# Altium schematic sheet SWITCHING.SchDoc
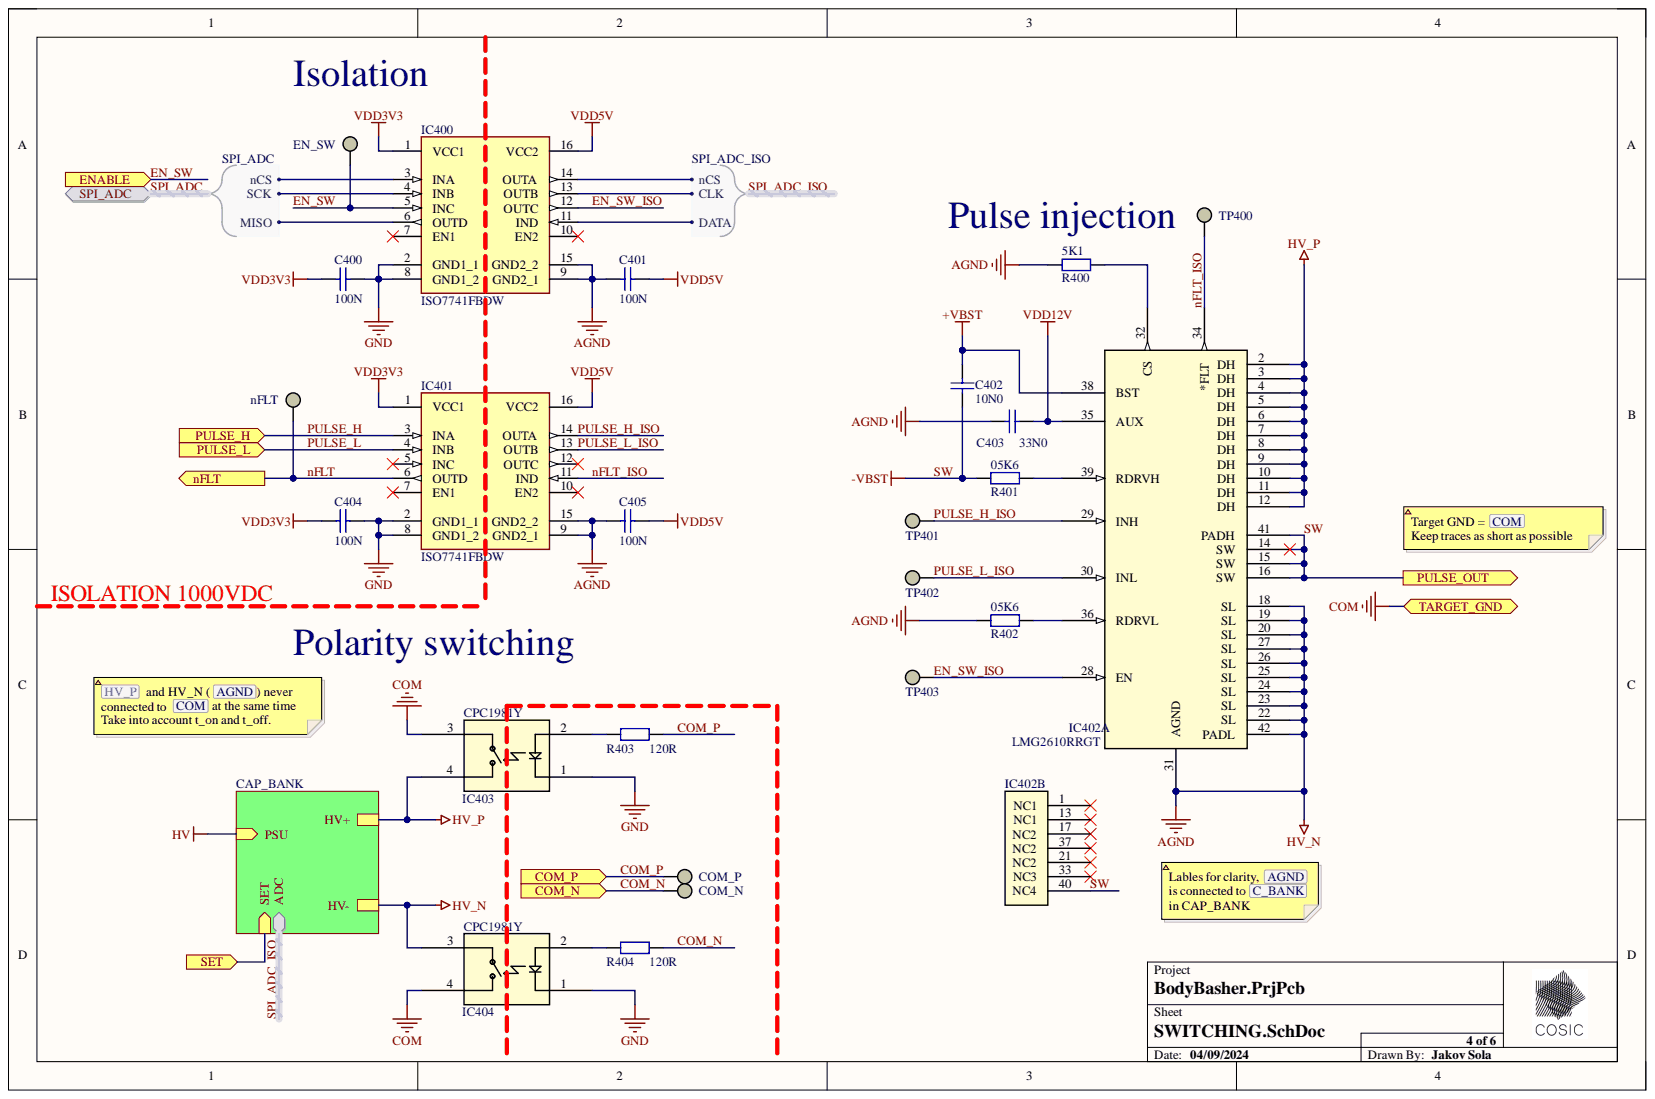
[diagram p0: SWITCHING.SchDoc]

## Parts
C400  value 100N  [CAPC0603N]  (hidden comment: Cap)
C401  value 100N  [CAPC0603N]  (hidden comment: Cap)
C402  VALUE_4C: 10N0  [CAPC0603N]  (hidden comment: Cap)
C403  VALUE_4C: 33N0  [CAPC0603N]  (hidden comment: Cap)
C404  value 100N  [CAPC0603N]  (hidden comment: Cap)
C405  value 100N  [CAPC0603N]  (hidden comment: Cap)
COM_N  TP  [TP]  (value not drawn)
COM_P  TP  [TP]  (value not drawn)
EN_SW  TP  [TP]  (value not drawn)
IC400  ISO7741FBDW  [SOIC16]
IC401  ISO7741FBDW  [SOIC16]
IC402  LMG2610RRGT  [XLMG2610RRGT]  (parts A,B)
IC403  SSR_SPST_NO  [CPC1981Y]  (value not drawn)
IC404  SSR_SPST_NO  [CPC1981Y]  (value not drawn)
nFLT  TP  [TP]  (value not drawn)
R400  value 5K1  [RESC0603N]  (hidden comment: Res)
R401  VALUE_4C: 05K6  [RESC0603N]  (hidden comment: Res)
R402  VALUE_4C: 05K6  [RESC0603N]  (hidden comment: Res)
R403  value 120R  [RESC0603N]  (hidden comment: Res)
R404  value 120R  [RESC0603N]  (hidden comment: Res)
TP400  TP  [TP]
TP401  TP  [TP]
TP402  TP  [TP]
TP403  TP  [TP]

## Ports
COM_N, COM_P, ENABLE, nFLT, PULSE_H, PULSE_L, PULSE_OUT, SET, SPI_ADC, SPI_ADC.MISO, SPI_ADC.nCS, SPI_ADC.SCK, TARGET_GND

## Nets
+VBST: C402.2 IC402.38(BST)
AGND: C401.2 C403.1 C405.2 IC400.9(GND2_1) IC400.15(GND2_2) IC401.9(GND2_1) IC401.15(GND2_2) IC402.18(SL) IC402.19(SL) IC402.20(SL) IC402.22(SL) IC402.23(SL) IC402.24(SL) IC402.25(SL) IC402.26(SL) IC402.27(SL) IC402.31(AGND) IC402.42(PADL) IC404.3 R400.1 R402.1
GND: C400.1 C404.1 IC400.2(GND1_1) IC400.8(GND1_2) IC401.2(GND1_1) IC401.8(GND1_2) IC403.1 IC404.1
HV_P: IC402.2(DH) IC402.3(DH) IC402.4(DH) IC402.5(DH) IC402.6(DH) IC402.7(DH) IC402.8(DH) IC402.9(DH) IC402.10(DH) IC402.11(DH) IC402.12(DH) IC403.4
VDD3V3: C400.2 C404.2 IC400.1(VCC1) IC401.1(VCC1)
VDD5V: C401.1 C405.1 IC400.16(VCC2) IC401.16(VCC2)
VDD12V: C403.2 IC402.35(AUX)
COM: IC403.3 IC404.4 ; port TARGET_GND
COM_N: COM_N.TP R404.2 ; port COM_N
COM_P: COM_P.TP R403.2 ; port COM_P
EN_SW: EN_SW.TP IC400.5(INC) ; port ENABLE
EN_SW_ISO: IC400.12(OUTC) IC402.28(EN) TP403.TP
nFLT: IC401.6(OUTD) nFLT.TP ; port nFLT
nFLT_ISO: IC401.11(IND) IC402.34(*FLT) TP400.TP
PULSE_H: IC401.3(INA) ; port PULSE_H
PULSE_H_ISO: IC401.14(OUTA) IC402.29(INH) TP401.TP
PULSE_L: IC401.4(INB) ; port PULSE_L
PULSE_L_ISO: IC401.13(OUTB) IC402.30(INL) TP402.TP
SPI_ADC.MISO: IC400.6(OUTD) ; port SPI_ADC
SPI_ADC.nCS: IC400.3(INA) ; port SPI_ADC
SPI_ADC.SCK: IC400.4(INB) ; port SPI_ADC
SPI_ADC_ISO.CLK: IC400.13(OUTB)
SPI_ADC_ISO.DATA: IC400.11(IND)
SPI_ADC_ISO.nCS: IC400.14(OUTA)
SW: C402.1 IC402.14(SW) IC402.15(SW) IC402.16(SW) IC402.40(NC4) IC402.41(PADH) R401.1 ; port PULSE_OUT
NetIC400_7: IC400.7(EN1)
NetIC400_10: IC400.10(EN2)
NetIC401_5: IC401.5(INC)
NetIC401_7: IC401.7(EN1)
NetIC401_10: IC401.10(EN2)
NetIC401_12: IC401.12(OUTC)
NetIC402_1: IC402.1(NC1)
NetIC402_13: IC402.13(NC1)
NetIC402_17: IC402.17(NC2)
NetIC402_21: IC402.21(NC2)
NetIC402_32: IC402.32(CS) R400.2
NetIC402_33: IC402.33(NC3)
NetIC402_36: IC402.36(RDRVL) R402.2
NetIC402_37: IC402.37(NC2)
NetIC402_39: IC402.39(RDRVH) R401.2
NetIC403_2: IC403.2 R403.1
NetIC404_2: IC404.2 R404.1
HV:
SET: ; port SET
SPI_ADC_ISO.MISO:
SPI_ADC_ISO.SCK:

## Library data of the parts
C400 C401 C404 C405 = CL10B104KA8WPNC: MANUF: SAMSUNG; Database Table Name: Fixed_capacitors$; DESCR: CAP 100N 0603 25V X7R 10%; POPULATE: YES; TOLERANCE: 0.1; VENDOR: DIGI-KEY; VENDORCODE: 1276-6717-1-ND; VOLTAGE: 25V; Component Kind: Standard; Library Name: Capacitors.DbLib; MANUFCODE: CL10B104KA8WPNC; PACKAGE: 0603; TECHNOLOGY: X7R; VALUE_4C: 100N
C402 = CGA3E2C0G1H103J080AA: MANUF: TDK; Database Table Name: Fixed_capacitors$; DESCR: CAP 10N0 0603 50V NP0 5%; POPULATE: YES; TOLERANCE: 0.05; VALUE: 10N; VALUE_PF: 10000; VENDOR: FARNELL INONE; VENDORCODE: 2210846; VOLTAGE: 50V; Component Kind: Standard; Library Name: Capacitors.DbLib; MANUFCODE: CGA3E2C0G1H103J080AA; PACKAGE: 0603; TECHNOLOGY: NP0
C403 = CL10B333KB8NFNC: MANUF: SAMSUNG; Database Table Name: Fixed_capacitors$; DESCR: CAP 33N0 0603 50V X7R 10%; POPULATE: YES; TOLERANCE: 0.1; VALUE: 33N; VENDOR: DIGI-KEY; VENDORCODE: 1276-2040-1-ND; VOLTAGE: 50V; Component Kind: Standard; Library Name: Capacitors.DbLib; MANUFCODE: CL10B333KB8NFNC; PACKAGE: 0603; TECHNOLOGY: X7R
COM_N COM_P EN_SW nFLT TP400-TP403 = TP: Database Table Name: Misc$; DESCR: TESTPOINT 1.5MM; POPULATE: NA; Component Kind: Standard; Library Name: Other_passive.Dblib; MANUFCODE: TP
IC400 IC401 = ISO7741FBDW: MANUF: TI; Database Table Name: IC$; DESCR: IC ISO 3/1 100MBPS 2.25-5.5 V; POPULATE: YES; VENDOR: MOUSER; VENDORCODE: 595-ISO7741FBDW; Component Kind: Standard; Library Name: Integrated_circuits.DbLib; MANUFCODE: ISO7741FBDW
IC402 = LMG2610RRGT: MANUF: TEXAS INSTRUMENTS; Database Table Name: IC$; DESCR: IC INT HALF BRIDGE GAN; POPULATE: YES; VENDOR: MOUSER; VENDORCODE: 595-LMG2610RRGT; Component Kind: Standard; Library Name: Integrated_circuits.DbLib; MANUFCODE: LMG2610RRGT
IC403 IC404 = CPC1981Y: MANUF: IXYS; Database Table Name: IC$; DESCR: IC SSR SPST NO 1000V 180mA; POPULATE: YES; VENDOR: MOUSER; VENDORCODE: 849-CPC1981Y; Component Kind: Standard; Library Name: Integrated_circuits.DbLib; MANUFCODE: CPC1981Y
R400 = MC 0.063W 0603 1% 5K1: Resistor; MANUF: MULTICOMP; Database Table Name: Fixed_resistors$; DESCR: RES 05K1 0603 0.063W 1% 50V 100PPM; NPOWER: 0.063; NTEMPCOEFF: 100; NTOLERANCE: 0.01; NVALUE: 5100000; NVOLTAGE: 50; POPULATE: YES; POWER: 0.063W; TEMPCOEFF: 100PPM; TOLERANCE: 1%; VALUE_4C: 05K1; VENDOR: FARNELL INONE; VENDORCODE: 9331301; VOLTAGE: 50V; Component Kind: Standard; Library Name: Resistors.Dblib; MANUFCODE: MC 0.063W 0603 1% 5K1; PACKAGE: 0603; PCB3D: RES0603; VALUE_MOHM: 5100000
R401 R402 = MC 0.063W 0603 1% 5K6: Resistor; MANUF: MULTICOMP; Database Table Name: Fixed_resistors$; DESCR: RES 05K6 0603 0.063W 1% 50V 100PPM; NPOWER: 0.063; NTEMPCOEFF: 100; NTOLERANCE: 0.01; NVALUE: 5600000; NVOLTAGE: 50; POPULATE: YES; POWER: 0.063W; TEMPCOEFF: 100PPM; TOLERANCE: 1%; VALUE: 5K6; VENDOR: FARNELL INONE; VENDORCODE: 9331352; VOLTAGE: 50V; Component Kind: Standard; Library Name: Resistors.Dblib; MANUFCODE: MC 0.063W 0603 1% 5K6; PACKAGE: 0603; PCB3D: RES0603; VALUE_MOHM: 5600000
R403 R404 = CRCW0603120RFKEA: Resistor; MANUF: VISHAY; Database Table Name: Fixed_resistors$; DESCR: RES 120R 0603 0.1W 1% 75V 100PPM; NPOWER: 0.1; NTEMPCOEFF: 100; NTOLERANCE: 0.01; NVALUE: 120000; NVOLTAGE: 75; POPULATE: YES; POWER: 0.1W; TEMPCOEFF: 100PPM; TOLERANCE: 1%; VENDOR: DIGI-KEY; VENDORCODE: 541-120HCT-ND; VOLTAGE: 75V; Component Kind: Standard; Library Name: Resistors.Dblib; MANUFCODE: CRCW0603120RFKEA; PACKAGE: 0603; VALUE_4C: 120R; VALUE_MOHM: 120000
